# Altium schematic sheet [00] ESP8266 CH340.SchDoc("[00] ESP8266 CH340")
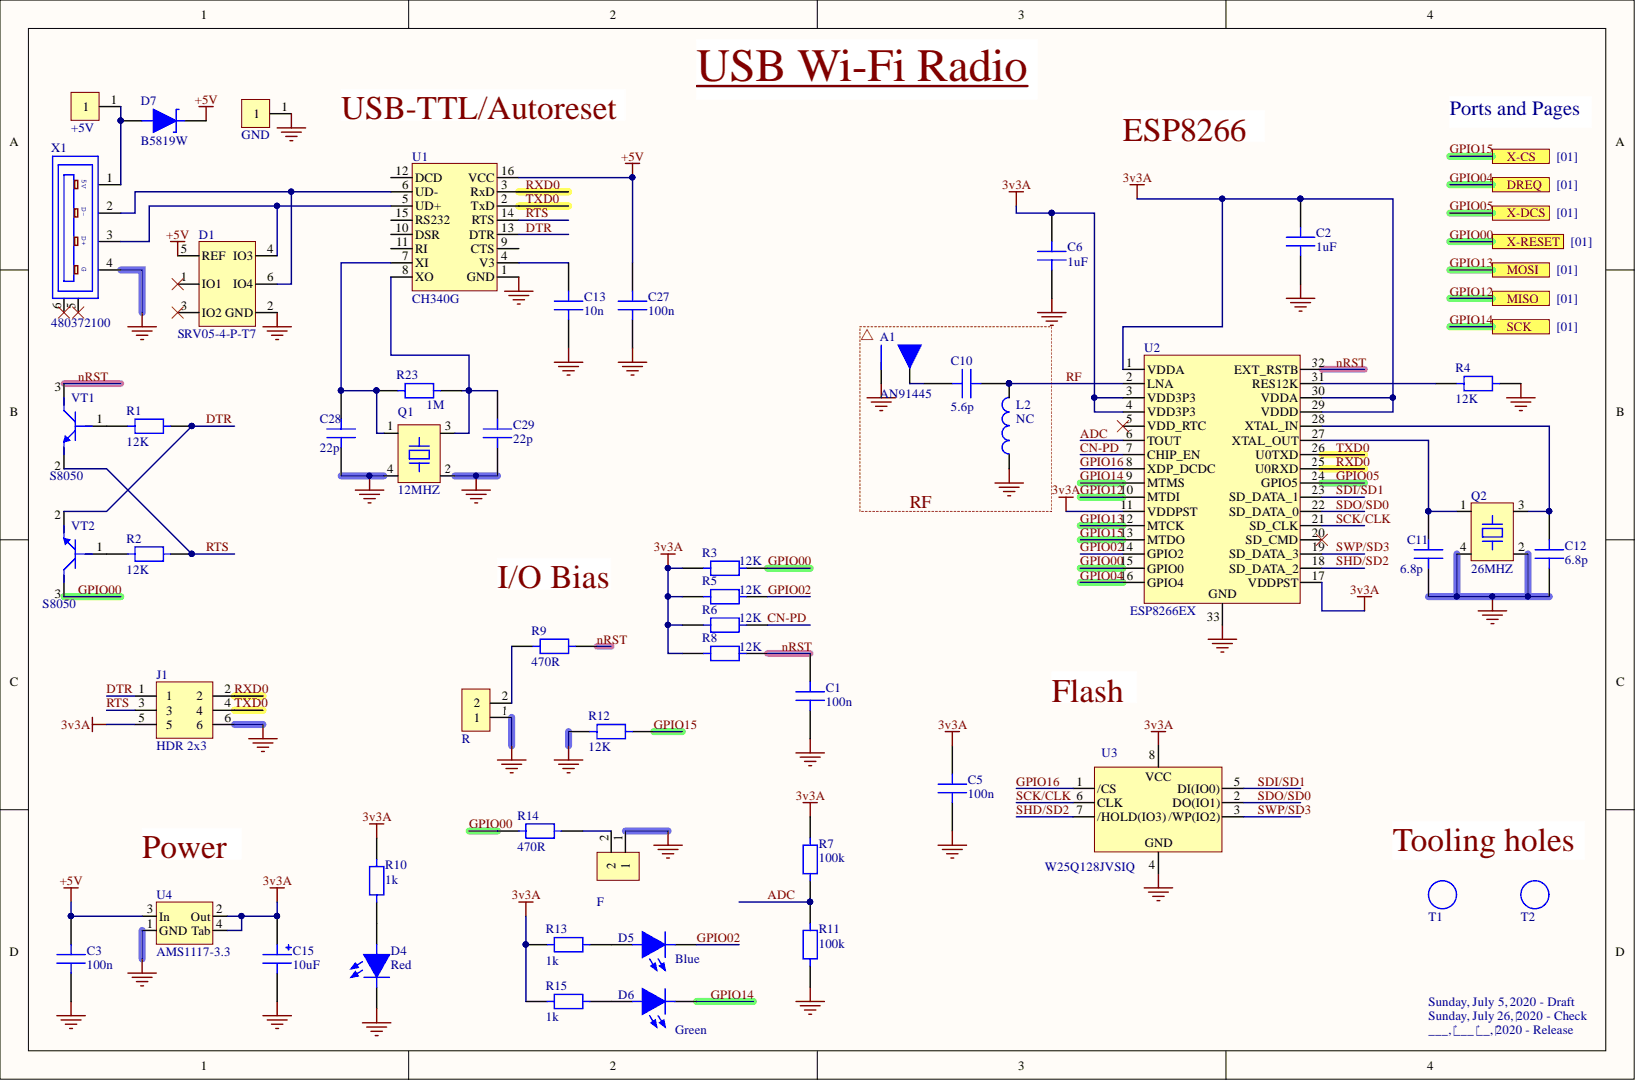
[diagram p0: [00] ESP8266 CH340.SchDoc]

## Parts
A1  AN91445  [MIFA 2.4GHz]  (no BOM)
C1  100n  [0603 CAP]
C2  1uF  [0402]
C3  100n  [0603 CAP]
C5  100n  [0603 CAP]
C6  1uF  [0402]
C10  5.6p  [CAP 0402]
C11  6.8p  [0402]
C12  6.8p  [0402]
C13  10n  [0603 CAP]
C15  10uF  [CAP 1206]
C27  100n  [0603 CAP]
C28  22p  [0603 CAP]
C29  22p  [0603 CAP]
D1  SRV05-4-P-T7  [SOT-23-6]
D4  Red  [LED 0603]
D5  Blue  [LED 0603]
D6  Green  [LED 0603]
D7  B5819W  [SOD-123]
J1  HDR 2x3  [HDR 2x3 2.54mm]
JP1  R  [Hdr_Fem_1x2_2.54mm]
JP2  F  [Hdr_Fem_1x2_2.54mm]
JP3  +5V  [Hdr_Fem_1x1_2.54mm]
JP4  GND  [Hdr_Fem_1x1_2.54mm]
L2  NC  [IND 0402]
Q1  12MHZ  [ABM8W]
Q2  26MHZ  [ABM8W]
R1  12K  [0603 RES]
R2  12K  [0603 RES]
R3  12K  [0603 RES]
R4  12K  [0402 RES]
R5  12K  [0603 RES]
R6  12K  [0603 RES]
R7  100k  [0603 RES]
R8  12K  [0603 RES]
R9  470R  [0603 RES]
R10  1k  [0603 RES]
R11  100k  [0603 RES]
R12  12K  [0603 RES]
R13  1k  [0603 RES]
R14  470R  [0603 RES]
R15  1k  [0603 RES]
R23  1M  [0603 RES]
T1  Tooling hole  [Tooling]  (no BOM)
T2  Tooling hole  [Tooling]  (no BOM)
U1  CH340G  [SOP-16]
U2  ESP8266EX  [QFN-32 Thermal]
U3  W25Q128JVSIQ  [SOIC-8 208-mil]
U4  AMS1117-3.3  [SOT233-3]
VT1  S8050  [SOT-23]
VT2  S8050  [SOT-23]
X1  480372100  [USB2.0-A]

## Ports
DREQ, MISO, MOSI, SCK, X-CS, X-DCS, X-RESET

## Nets
3v3A: C2.2 C5.1 C6.2 C15.1 J1.5 R3.1 R5.1 R6.1 R7.2 R8.1 R10.2 R13.1 R15.1 U2.1(VDDA) U2.3(VDD3P3) U2.4(VDD3P3) U2.11(VDDPST) U2.17(VDDPST) U2.29(VDDD) U2.30(VDDA) U3.8 U4.2(Out) U4.4(Tab)
+5V: C3.2 C27.2 D1.5(REF) D7.2 U1.16(VCC) U4.3(In)
GND: A1.2 C1.1 C2.1 C3.1 C5.2 C6.1 C11.1 C12.1 C13.1 C15.2 C27.1 C28.1 C29.1 D1.2(GND) D4.2 J1.6 JP1.1 JP2.1 JP4.1 L2.1 Q1.2 Q1.4 Q2.2 Q2.4 R4.2 R11.1 R12.1 U1.1(GND) U2.33 U3.4 U4.1(GND) X1.4(G)
ADC: R7.1 R11.2 U2.6(TOUT)
CN-PD: R6.2 U2.7(CHIP_EN)
DTR: J1.1 R1.2 U1.13(DTR) VT2.2
GPIO00: R3.2 R14.1 U2.15(GPIO0) VT2.3 ; port X-RESET
GPIO02: D5.2 R5.2 U2.14(GPIO2)
GPIO04: U2.16(GPIO4) ; port DREQ
GPIO05: U2.24(GPIO5) ; port X-DCS
GPIO12: U2.10(MTDI) ; port MISO
GPIO13: U2.12(MTCK) ; port MOSI
GPIO14: D6.2 U2.9(MTMS) ; port SCK
GPIO15: R12.2 U2.13(MTDO) ; port X-CS
GPIO16: U2.8(XDP_DCDC) U3.1(/CS)
nRST: C1.2 R8.2 R9.2 U2.32(EXT_RSTB) VT1.3
RF: C10.2 L2.2 U2.2(LNA)
RTS: J1.3 R2.2 U1.14(RTS) VT1.2
RXD0: J1.2 U1.3(RxD) U2.25(U0RXD)
SCK/CLK: U2.21(SD_CLK) U3.6(CLK)
SDI/SD1: U2.23(SD_DATA_1) U3.5(DI(IO0))
SDO/SD0: U2.22(SD_DATA_0) U3.2(DO(IO1))
SHD/SD2: U2.18(SD_DATA_2) U3.7(/HOLD(IO3))
SWP/SD3: U2.19(SD_DATA_3) U3.3(/WP(IO2))
TXD0: J1.4 U1.2(TxD) U2.26(U0TXD)
NetA1_1: A1.1 C10.1
NetC11_2: C11.2 Q2.1 U2.27(XTAL_OUT)
NetC12_2: C12.2 Q2.3 U2.28(XTAL_IN)
NetC13_2: C13.2 U1.4(V3)
NetC28_2: C28.2 Q1.1 R23.1 U1.7(XI)
NetC29_2: C29.2 Q1.3 R23.2 U1.8(XO)
NetD1_4: D1.4(IO3) U1.5(UD+) X1.3(D+)
NetD1_6: D1.6(IO4) U1.6(UD-) X1.2(D-)
NetD4_1: D4.1 R10.1
NetD5_1: D5.1 R13.2
NetD6_1: D6.1 R15.2
NetD7_1: D7.1 JP3.1 X1.1(5V)
NetJP1_2: JP1.2 R9.1
NetJP2_2: JP2.2 R14.2
NetR1_1: R1.1 VT1.1
NetR2_1: R2.1 VT2.1
NetR4_1: R4.1 U2.31(RES12K)

Not connected: D1 1(IO1) 3(IO2); U1 9(CTS) 10(DSR) 11(RI) 12(DCD) 15(RS232); U2 5(VDD_RTC) 20(SD_CMD); X1 5 6

## Library data of the parts
A1 = MIFA 2.4GHz: ComponentLink1Description: rf-layout-guidelines; ComponentLink1URL: https://www.cypress.com/documentation/application-notes/an91445-antenna-design-and-rf-layout-guidelines; Description: AN91445; Library Name: WiFi_Lib.SchLib
C1 C3 C5 C27 = CC0603KRX7R9BB104: MULTILAYER CERAMIC CAPACITORS MLCC - SMD/SMT 100NF 50V 0603 ROHS; Manufacturer: YAGEO; Category: Capacitors; Component Kind: Standard; Datasheet: https://datasheet.lcsc.com/szlcsc/YAGEO-CC0603KRX7R9BB104_C14663.pdf; LCSC Part #: C14663; Library Name: JLC_Lib.SchLib; MFR.Part #: CC0603KRX7R9BB104; Package: 0603
C2 C6 = CL05A105KA5NQNC: MULTILAYER CERAMIC CAPACITORS MLCC - SMD/SMT 1UF 25V 0402 ROHS; Manufacturer: Samsung Electro-Mechanics; Category: Capacitors; Component Kind: Standard; Datasheet: https://datasheet.lcsc.com/szlcsc/Samsung-Electro-Mechanics-CL05A105KA5NQNC_C52923.pdf; LCSC Part #: C52923; Library Name: JLC_Lib.SchLib; MFR.Part #: CL05A105KA5NQNC; Package: 0402
C10 = CL05B103KB5NNNC_1: 5.6PF ±0.25PF 50V C0G 0402 MULTILAYER CERAMIC CAPACITORS MLCC - SMD/SMT ROHS; Manufacturer: Samsung Electro-Mechanics; MFR.Part #: CL05C5R6CB5NNNC; Library Type: Extended; Category: Capacitors; Component Kind: Standard; ComponentLink1Description: CL05C5R6CB5NNNC_C318596.pdf; ComponentLink1URL: https://datasheet.lcsc.com/szlcsc/1811131657_Samsung-Electro-Mechanics-CL05C5R6CB5NNNC_C318596.pdf; Datasheet: https://datasheet.lcsc.com/szlcsc/1811131657_Samsung-Electro-Mechanics-CL05C5R6CB5NNNC_C318596.pdf; LCSC Part #: C318596; Library Name: JLC_Lib.SchLib; Package: 0402
C11 C12 = 0402CG6R8C500NT: MULTILAYER CERAMIC CAPACITORS MLCC - SMD/SMT 6.8PF 50V 0402 ROHS; Manufacturer: Guangdong Fenghua Advanced Tech; Category: Capacitors; Component Kind: Standard; Datasheet: https://datasheet.lcsc.com/szlcsc/Guangdong-Fenghua-Advanced-Tech-0402CG6R8C500NT_C1576.pdf; LCSC Part #: C1576; Library Name: JLC_Lib.SchLib; MFR.Part #: 0402CG6R8C500NT; Package: 0402
C13 = 0603B103K500NT: MULTILAYER CERAMIC CAPACITORS MLCC - SMD/SMT 10NF 50V 0603 ROHS; Manufacturer: Guangdong Fenghua Advanced Tech; Category: Capacitors; Component Kind: Standard; Datasheet: https://datasheet.lcsc.com/szlcsc/Guangdong-Fenghua-Advanced-Tech-0603B103K500NT_C57112.pdf; LCSC Part #: C57112; Library Name: JLC_Lib.SchLib; MFR.Part #: 0603B103K500NT; Package: 0603
C15 = TAJA106K016RNJ: TANTALUM CAPACITORS 10UF 16V CASE-A_3216 ROHS; Manufacturer: AVX; Category: Capacitors; Component Kind: Standard; Datasheet: https://datasheet.lcsc.com/szlcsc/AVX-TAJA106K016RNJ_C7171.pdf; LCSC Part #: C7171; Library Name: JLC_Lib.SchLib; MFR.Part #: TAJA106K016RNJ; Package: CASE-A_3216
C28 C29 = CL10C220JB8NNNC: MULTILAYER CERAMIC CAPACITORS MLCC - SMD/SMT 22PF 50V 0603 ROHS; Manufacturer: Samsung Electro-Mechanics; Category: Capacitors; Component Kind: Standard; Datasheet: https://datasheet.lcsc.com/szlcsc/Samsung-Electro-Mechanics-CL10C220JB8NNNC_C1653.pdf; LCSC Part #: C1653; Library Name: JLC_Lib.SchLib; MFR.Part #: CL10C220JB8NNNC; Package: 0603
D1 = SRV05-4-P-T7: TVS SOT-23-6 ROHS; Manufacturer: ProTek Devices; Category: Diodes; Component Kind: Standard; ComponentLink1Description: SRV05-4-P-T7_C85364; ComponentLink1URL: https://datasheet.lcsc.com/szlcsc/ProTek-Devices-SRV05-4-P-T7_C85364.pdf; Datasheet: https://datasheet.lcsc.com/szlcsc/ProTek-Devices-SRV05-4-P-T7_C85364.pdf; LCSC Part #: C85364; Library Name: JLC_Lib.SchLib; MFR.Part #: SRV05-4-P-T7; Package: SOT-23-6L
D4 = KT-0603R: LIGHT EMITTING DIODES (LED) RED 520~625NM 90~100MCD@20MA TOP VIEW 0603 ROHS; Manufacturer: Hubei KENTO Elec; Category: LED's; Component Kind: Standard; Datasheet: https://datasheet.lcsc.com/szlcsc/Hubei-KENTO-Elec-KT-0603R_C2286.pdf; LCSC Part #: C2286; Library Name: JLC_Lib.SchLib; MFR.Part #: KT-0603R; Package: LED_0603
D5 = 19-217/BHC-ZL1M2RY/3T: LIGHT EMITTING DIODES (LED) BLUE 465~475NM 11.5~28.5MCD@5MA TOP VIEW 0603 ROHS; Manufacturer: Everlight Elec; Category: LED's; Component Kind: Standard; Datasheet: https://datasheet.lcsc.com/szlcsc/Everlight-Elec-19-217-BHC-ZL1M2RY-3T_C72041.pdf; LCSC Part #: C72041; Library Name: JLC_Lib.SchLib; MFR.Part #: 19-217/BHC-ZL1M2RY/3T; Package: LED_0603
D6 = 19-217/GHC-YR1S2/3T: LIGHT EMITTING DIODES (LED) GREEN 520~535NM 112~258MCD@20MA TOP VIEW 0603 ROHS; Manufacturer: Everlight Elec; Category: LED's; Component Kind: Standard; Datasheet: https://datasheet.lcsc.com/szlcsc/Everlight-Elec-19-217-GHC-YR1S2-3T_C72043.pdf; LCSC Part #: C72043; Library Name: JLC_Lib.SchLib; MFR.Part #: 19-217/GHC-YR1S2/3T; Package: LED_0603
D7 = B5819W: SCHOTTKY BARRIER DIODES (SBD) SOD-123 ROHS; Manufacturer: Changjiang Electronics Tech (CJ); Category: Diodes; Component Kind: Standard; Datasheet: https://datasheet.lcsc.com/szlcsc/Changjiang-Electronics-Tech-CJ-B5819W_C8598.pdf; LCSC Part #: C8598; Library Name: JLC_Lib.SchLib; MFR.Part #: B5819W; Package: SOD-123
J1 = 67997-106HLF: CONN HEADER 6POS .100 STR 30AU; Manufacturer 1: Amphenol FCI; Connector Type: Male Pin; Contact Post Length: 3.05mm; Current Rating: 3A; Gender: Male; Insulation Resistance: 5GR; Max Voltage Rating (AC): 1.5kV; Number of Contacts: 6; Pitch: 2.54mm; Row Spacing: 0.1inch; Category: Headers and Wire Housings; Color: Black; Component Kind: Standard; ComponentLink1Description: https://datasheet.ciiva.com/5153/67996-222463-5153820.pdf?src-supplier=Mouser; ComponentLink1URL: https://datasheet.ciiva.com/5153/67996-222463-5153820.pdf?src-supplier=Mouser; ComponentLink2Description: https://datasheet.ciiva.com/16726/67996-16726286.pdf?src-supplier=Avnet+Europe; ComponentLink2URL: https://datasheet.ciiva.com/16726/67996-16726286.pdf?src-supplier=Avnet+Europe; ComponentLink3Description: https://datasheet.ciiva.com/722/67996-722044.pdf?src-supplier=Digi-Key; ComponentLink3URL: https://datasheet.ciiva.com/722/67996-722044.pdf?src-supplier=Digi-Key; ComponentLink4Description: https://datasheet.ciiva.com/10149/67996-10149221.pdf?src-supplier=Avnet; ComponentLink4URL: https://datasheet.ciiva.com/10149/67996-10149221.pdf?src-supplier=Avnet; ComponentLink5Description: https://datasheet.ciiva.com/46393/1876884-46393067.pdf?src-supplier=Element14; ComponentLink5URL: https://datasheet.ciiva.com/46393/1876884-46393067.pdf?src-supplier=Element14; Contact Gender: Male; Contact Material: Bronze; Contact Mating Length: 0.23inch; Contact Plating: Gold,GXT; Depth: 4.83mm; Flammability Rating: UL94 V-0; Housing Color: Black; Housing Material: Thermoplastic; Lead Free: Lead Free; Length: 7.62mm; Library Name: WiFi_Lib.SchLib; Manufacturer Part Number 1: 67997-106HLF; Max Operating Temperature: 125°C; Min Operating Temperature: -65°C; Mount: Through Hole; Number of Positions: 6; Number of Rows: 2; Orientation: Straight; Package Quantity: 100; Packaging: Bulk; Pricing 1: 1=1.78000, 10=1.61400, 100=1.38370, 500=1.15308, 1000=0.98835, 5000=0.87634, 10000=0.85657 (USD); Radiation Hardening: No; REACH SVHC: No SVHC; RoHS: Yes; Stock 1: 1665; Supplier 1: Digi-Key; Supplier Part Number 1: 609-3393-ND; Termination: Through Hole; Voltage Rating: 1.5kV
JP1 JP2 = 68602-204HLF: CONN HEADER VERT 2POS 2.54MM; Manufacturer 1: Amphenol FCI; Connector Type: Male Pin; Contact Post Length: 3.81mm; Current Rating: 3A; Gender: Male; Insulation Resistance: 5GR; Number of Contacts: 4; Row Spacing: 0.1inch; Voltage Rating: 1.5kV; Category: Headers and Wire Housings; Color: Black; Component Kind: Standard; ComponentLink1Description: https://datasheet.ciiva.com/728/67996-728884.pdf?src-supplier=Digi-Key; ComponentLink1URL: https://datasheet.ciiva.com/728/67996-728884.pdf?src-supplier=Digi-Key; Contact Gender: Male; Contact Material: Bronze; Contact Mating Length: 0.23inch; Contact Plating: Gold,GXT; Flammability Rating: UL94 V-0; Housing Material: Thermoplastic; Library Name: WiFi_Lib.SchLib; Manufacturer Part Number 1: 68602-204HLF; Max Operating Temperature: 125°C; Min Operating Temperature: -65°C; Mount: Through Hole; Number of Positions: 4; Number of Rows: 2; Package Quantity: 100; Packaging: Bulk; Pitch: 2.54mm; Pricing 1: 1=0.34000, 10=0.31900, 100=0.22320, 500=0.19132, 1000=0.15943, 5000=0.13665, 10000=0.12754 (USD); Radiation Hardening: No; RoHS: Yes; Stock 1: 139; Supplier 1: Digi-Key; Supplier Part Number 1: 609-3369-ND; Termination: Solder
JP3 JP4 = 68602-101HLF: CONN HEADER VERT 1POS 2.54MM; Manufacturer 1: Amphenol FCI; Manufacturer Part Number 1: 68602-204HLF; Connector Type: Male Pin; Contact Post Length: 3.81mm; Current Rating: 3A; Gender: Male; Insulation Resistance: 5GR; Number of Contacts: 4; Row Spacing: 0.1inch; Voltage Rating: 1.5kV; Category: Headers and Wire Housings; Color: Black; Component Kind: Standard; ComponentLink1Description: https://datasheet.ciiva.com/728/67996-728884.pdf?src-supplier=Digi-Key; ComponentLink1URL: https://datasheet.ciiva.com/728/67996-728884.pdf?src-supplier=Digi-Key; Contact Gender: Male; Contact Material: Bronze; Contact Mating Length: 0.23inch; Contact Plating: Gold,GXT; Flammability Rating: UL94 V-0; Housing Material: Thermoplastic; Library Name: WiFi_Lib.SchLib; Max Operating Temperature: 125°C; Min Operating Temperature: -65°C; Mount: Through Hole; Number of Positions: 4; Number of Rows: 2; Package Quantity: 100; Packaging: Bulk; Pitch: 2.54mm; Pricing 1: 1=0.34000, 10=0.31900, 100=0.22320, 500=0.19132, 1000=0.15943, 5000=0.13665, 10000=0.12754 (USD); Radiation Hardening: No; RoHS: Yes; Stock 1: 139; Supplier 1: Digi-Key; Supplier Part Number 1: 609-3369-ND; Termination: Solder
L2 = NC IND: IND 0402 Matching; Component Kind: Standard; Library Name: WiFi_Lib.SchLib
Q1 = X322512MSB4SI: SMD CRYSTAL RESONATORS 12MHZ ±10PPM SMD-3225_4P ROHS; Manufacturer: Yangxing Tech; Category: Crystals; Component Kind: Standard; ComponentLink1Description: X322512MSB4SI_C9002.pdf; ComponentLink1URL: https://datasheet.lcsc.com/szlcsc/Yangxing-Tech-X322512MSB4SI_C9002.pdf; Datasheet: https://datasheet.lcsc.com/szlcsc/Yangxing-Tech-X322512MSB4SI_C9002.pdf; LCSC Part #: C9002; Library Name: JLC_Lib.SchLib; MFR.Part #: X322512MSB4SI; Package: SMD-3225_4P
Q2 = X322526MEB4SC: SMD CRYSTAL RESONATORS 26MHZ ±10PPM SMD-3225_4P ROHS; Manufacturer: Yangxing Tech; Category: Crystals; Component Kind: Standard; Datasheet: https://datasheet.lcsc.com/szlcsc/Yangxing-Tech-X322526MEB4SC_C23425.pdf; LCSC Part #: C23425; Library Name: JLC_Lib.SchLib; MFR.Part #: X322526MEB4SC; Package: SMD-3225_4P
R1-R3 R5 R6 R8 R12 = 0603WAF1202T5E: CHIP RESISTOR - SURFACE MOUNT 12KOHMS ±1% 1/10W 0603 ROHS; Manufacturer: Uniroyal Elec; Category: Resistors; Component Kind: Standard; Datasheet: https://datasheet.lcsc.com/szlcsc/Uniroyal-Elec-0603WAF1202T5E_C22790.pdf; LCSC Part #: C22790; Library Name: JLC_Lib.SchLib; MFR.Part #: 0603WAF1202T5E; Package: 0603
R4 = 0402WGF1202TCE: CHIP RESISTOR - SURFACE MOUNT 12KOHMS ±1% 1/16W 0402 ROHS; Manufacturer: Uniroyal Elec; Category: Resistors; Component Kind: Standard; Datasheet: https://datasheet.lcsc.com/szlcsc/Uniroyal-Elec-0402WGF1202TCE_C25752.pdf; LCSC Part #: C25752; Library Name: JLC_Lib.SchLib; MFR.Part #: 0402WGF1202TCE; Package: 0402
R7 R11 = 0603WAF1003T5E: CHIP RESISTOR - SURFACE MOUNT 100KOHMS ±1% 1/10W 0603 ROHS; Manufacturer: Uniroyal Elec; Category: Resistors; Component Kind: Standard; Datasheet: https://datasheet.lcsc.com/szlcsc/Uniroyal-Elec-0603WAF1003T5E_C25803.pdf; LCSC Part #: C25803; Library Name: JLC_Lib.SchLib; MFR.Part #: 0603WAF1003T5E; Package: 0603
R9 R14 = 0603WAF4700T5E: CHIP RESISTOR - SURFACE MOUNT 470OHMS ±1% 1/10W 0603 ROHS; Manufacturer: Uniroyal Elec; Category: Resistors; Component Kind: Standard; Datasheet: https://datasheet.lcsc.com/szlcsc/Uniroyal-Elec-0603WAF4700T5E_C23179.pdf; LCSC Part #: C23179; Library Name: JLC_Lib.SchLib; MFR.Part #: 0603WAF4700T5E; Package: 0603
R10 R13 R15 = 0603WAF1001T5E: CHIP RESISTOR - SURFACE MOUNT 1KOHMS ±1% 1/10W 0603 ROHS; Manufacturer: Uniroyal Elec; Category: Resistors; Component Kind: Standard; Datasheet: https://datasheet.lcsc.com/szlcsc/Uniroyal-Elec-0603WAF1001T5E_C21190.pdf; LCSC Part #: C21190; Library Name: JLC_Lib.SchLib; MFR.Part #: 0603WAF1001T5E; Package: 0603
R23 = 0603WAF1004T5E: CHIP RESISTOR - SURFACE MOUNT 1MOHMS ±1% 1/10W 0603 ROHS; Manufacturer: Uniroyal Elec; Category: Resistors; Component Kind: Standard; Datasheet: https://datasheet.lcsc.com/szlcsc/Uniroyal-Elec-0603WAF1004T5E_C22935.pdf; LCSC Part #: C22935; Library Name: JLC_Lib.SchLib; MFR.Part #: 0603WAF1004T5E; Package: 0603
T1 T2 = Tooling hole: For JLCPCB SMT Assembly; Library Name: JLC_Lib.SchLib
U1 = CH340G: USB 2MBPS 3.3V, 5V SOP-16_150MIL ROHS; Manufacturer: Jiangsu Qin Heng; Category: IC's; Component Kind: Standard; Datasheet: https://datasheet.lcsc.com/szlcsc/Jiangsu-Qin-Heng-CH340G_C14267.pdf; LCSC Part #: C14267; Library Name: JLC_Lib.SchLib; MFR.Part #: CH340G; Package: SOIC-16_3.9x9.9x1.27P
U2 = ESP8266EX: RF TRANSCEIVER ICS TXRX + MCU WIFI 802.11B/G/N/E/I 2.4GHZ 16DBM - 91DBM 2.5V~3.6V QFN-32_5X5X05P ROHS; Manufacturer: Espressif Systems; Library Type: Extended; Category: IC's; Component Kind: Standard; Datasheet: https://datasheet.lcsc.com/szlcsc/Espressif-Systems-ESP8266EX_C77967.pdf; LCSC Part #: C77967; Library Name: JLC_Lib.SchLib; MFR.Part #: ESP8266EX; Package: QFN-32_EP_5.0x5.0x0.5P
U3 = W25Q128JVSIQ: FLASH FLASH 128MB (16M X 8) SPI - DUAL/QUAD I/O SOIC-8_208MIL ROHS; Manufacturer: Winbond Elec; Category: IC's; Component Kind: Standard; Datasheet: https://datasheet.lcsc.com/szlcsc/Winbond-Elec-W25Q128JVSIQTR_C97521.pdf; LCSC Part #: C97521; Library Name: JLC_Lib.SchLib; MFR.Part #: W25Q128JVSIQ; Package: SOIC-8_5.3x5.3x1.27P
U4 = AMS1117-3.3: LOW DROPOUT REGULATORS(LDO) POSITIVE FIXED 1.3V @ 800MA 15V 3.3V 1A SOT-223 ROHS; Manufacturer: Advanced Monolithic Systems; Category: IC's; Component Kind: Standard; ComponentLink1Description: AMS1117-3-3_C6186; ComponentLink1URL: https://datasheet.lcsc.com/szlcsc/1811201117_Advanced-Monolithic-Systems-AMS-AMS1117-3-3_C6186.pdf; Datasheet: https://datasheet.lcsc.com/szlcsc/1811201117_Advanced-Monolithic-Systems-AMS-AMS1117-3-3_C6186.pdf; LCSC Part #: C6186; Library Name: JLC_Lib.SchLib; MFR.Part #: AMS1117-3.3; Package: SOT-223
VT1 VT2 = S8050: TRANSISTORS (NPN/PNP) NPN 500MA 25V SOT-23(SOT-23-3) ROHS; Manufacturer: Changjiang Electronics Tech (CJ); Category: Transistors; Component Kind: Standard; Datasheet: https://datasheet.lcsc.com/szlcsc/Changjiang-Electronics-Tech-CJ-S8050_C2146.pdf; LCSC Part #: C2146; Library Name: JLC_Lib.SchLib; MFR.Part #: S8050; Package: SOT-23-3
X1 = 480372100: USB - Type A Male USB 2 4 Through Hole USB Connectors RoHS; Manufacturer: MOLEX; Category: Connectors; Component Kind: Standard; Datasheet: https://datasheet.lcsc.com/szlcsc/1811131824_MOLEX-480372100_C136453.pdf; LCSC Part #: C136453; Library Name: JLC_Lib.SchLib; MFR.Part #: 480372100; Package: Through Hole
